# Altium schematic sheet Sheet1.SchDoc
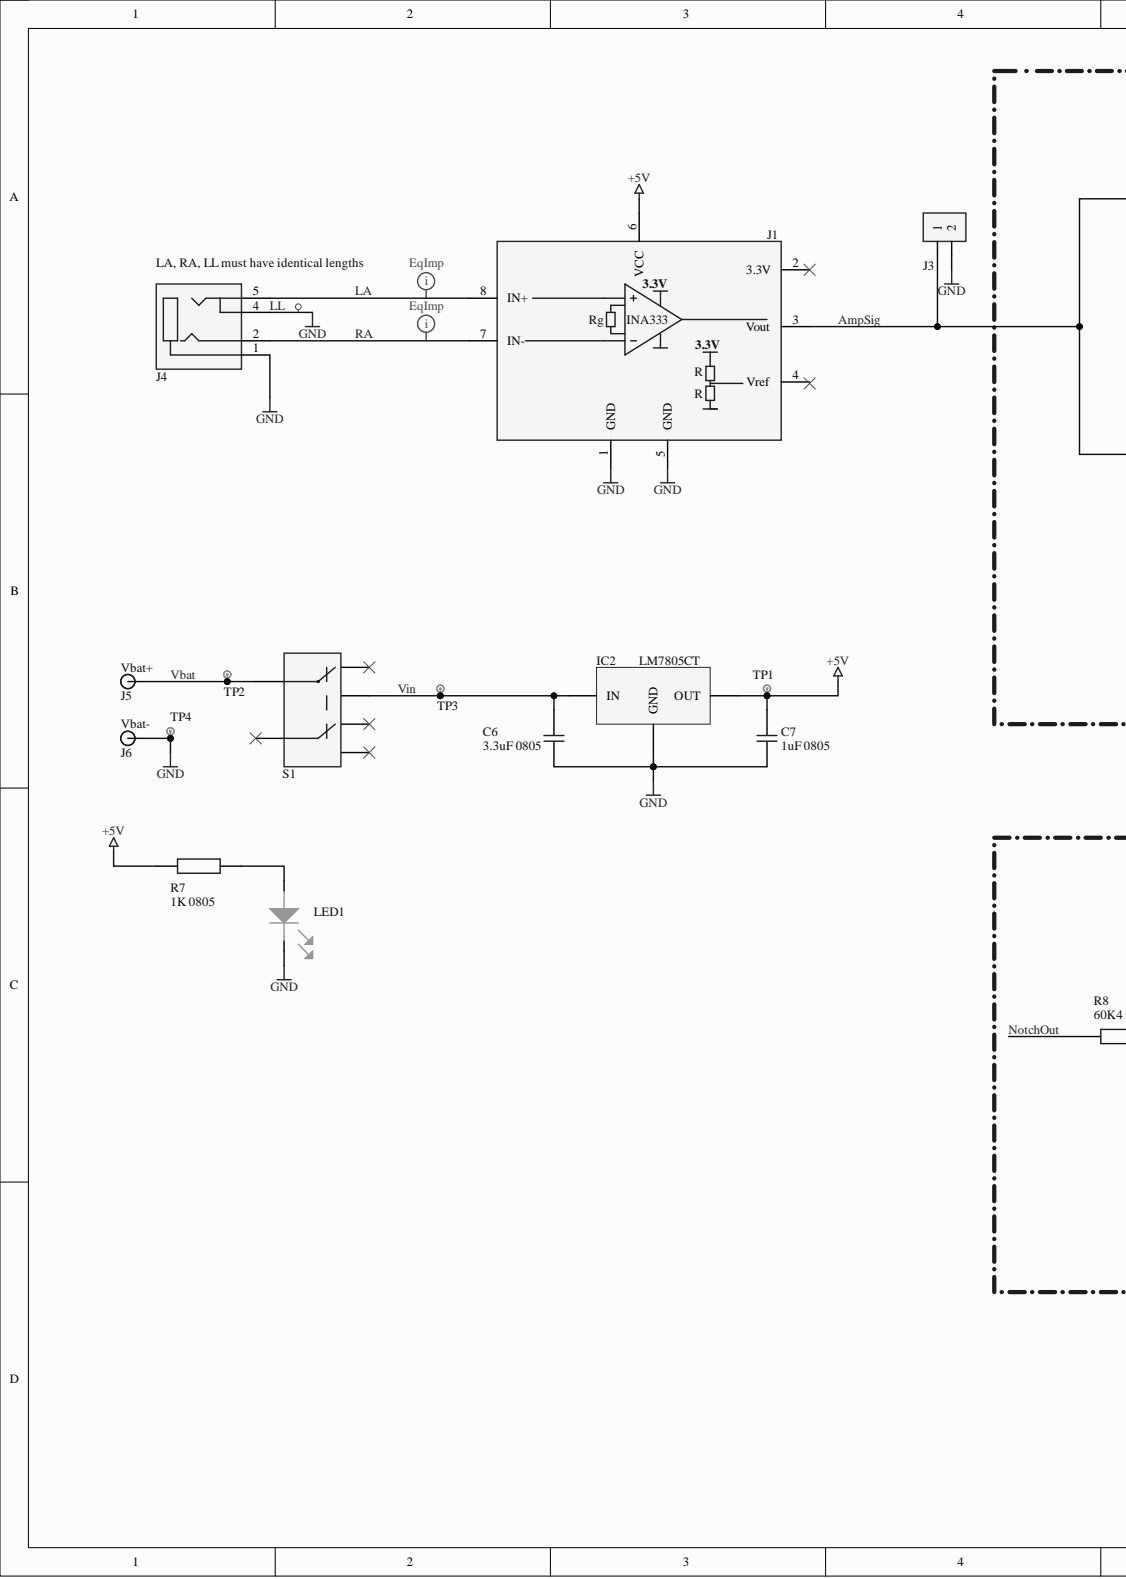
[diagram p0: Sheet1.SchDoc, left]
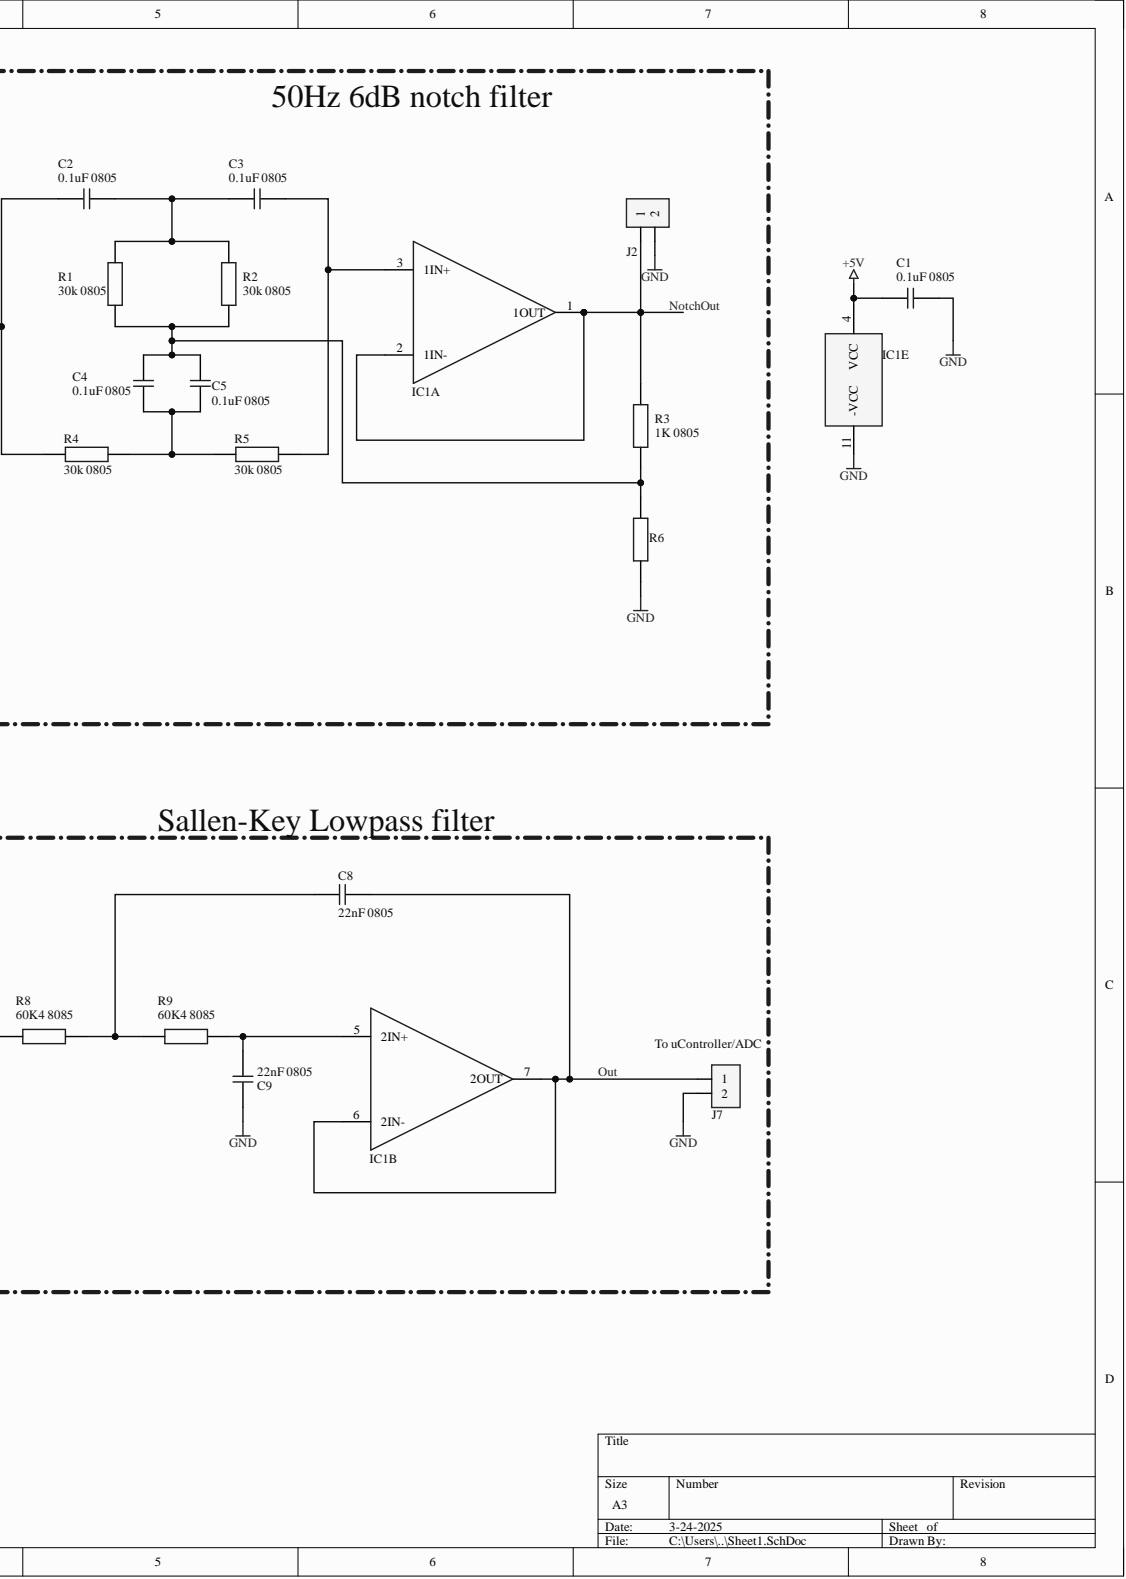
[diagram p1: Sheet1.SchDoc, right]

## Parts
C1  0.1uF 0805  Case/Package: 0805  [C0805 - XL]
C2  0.1uF 0805  Case/Package: 0805  [C0805 - XL]
C3  0.1uF 0805  Case/Package: 0805  [C0805 - XL]
C4  0.1uF 0805  Case/Package: 0805  [C0805 - XL]
C5  0.1uF 0805  Case/Package: 0805  [C0805 - XL]
C6  3.3uF 0805  value 3.3uF  Case/Package: 0805  [C0805 - XL]
C7  1uF 0805  value 1uF  Case/Package: 0805  [C0805 - XL]
C8  22nF 0805  value 22nF  Case/Package: 0805  [C0805 - XL]
C9  22nF 0805  value 22nF  Case/Package: 0805  [C0805 - XL]
IC1  LM324N  [DIP14 -  socket]  (value not drawn)  (parts A,B,E)
IC2  LM7805CT  [TO-220-3]
J1  INA333 Module  [INA333 - Module]  (value not drawn)  (no BOM)
J2  961102-6404-AR-HDR2-SIL  [HDR1X2-961102-6404-AR]  (value not drawn)
J3  961102-6404-AR-HDR2-SIL  [HDR1X2-961102-6404-AR]  (value not drawn)
J4  FC681374V  [FC681374V]  (value not drawn)
J5  Vbat+  [Soldering pads]  (no BOM)
J6  Vbat-  [Soldering pads]  (no BOM)
J7  961102-6404-AR-HDR2-SIL  [HDR1X2-961102-6404-AR]  (value not drawn)
LED1  Green LED  [LED 5mm THT]  (value not drawn)
R1  30k 0805  Case/Package: 0805  [R0805 - XL]
R2  30k 0805  Case/Package: 0805  [R0805 - XL]
R3  1K 0805  Case/Package: 0805  [R0805 - XL]
R4  30k 0805  Case/Package: 0805  [R0805 - XL]
R5  30k 0805  Case/Package: 0805  [R0805 - XL]
R6  19K1  [R0805 - XL]  (value not drawn)
R7  1K 0805  Case/Package: 0805  [R0805 - XL]
R8  60K4 8085  [R0805 - XL]
R9  60K4 8085  [R0805 - XL]
S1  SS22F03-G6  [SWDPDT-S22F03-G6]  (value not drawn)
TP1  Test pad  [Test pad 1mm]  (value not drawn)  (no BOM)
TP2  Test pad  [Test pad 1mm]  (value not drawn)  (no BOM)
TP3  Test pad  [Test pad 1mm]  (value not drawn)  (no BOM)
TP4  Test pad  [Test pad 1mm]  (value not drawn)  (no BOM)

## Nets
+5V: C1.2 C7.2 IC1.4(VCC) IC2.3 J1.6(VCC) R7.1 TP1.1
AmpSig: C2.1 J1.3(Vout) J3.1 R4.2
LA: J1.8(IN+) J4.5
LL: C1.1 C6.1 C7.1 C9.1 IC1.11(-VCC) IC2.2 J1.1(GND) J1.5(GND) J2.2 J3.2 J4.1 J4.4 J6.1 J7.2 LED1.2 R6.1 TP4.1
NotchOut: IC1.1(1OUT) IC1.2(1IN-) J2.1 R3.2 R8.1
Out: C8.1 IC1.6(2IN-) IC1.7(2OUT) J7.1
RA: J1.7(IN-) J4.2
Vbat: J5.1 S1.2 TP2.1
Vin: C6.2 IC2.1 S1.3 TP3.1
NetC2_2: C2.2 C3.1 R1.2 R2.2
NetC3_2: C3.2 IC1.3(1IN+) R5.1
NetC4_1: C4.1 C5.1 R4.1 R5.2
NetC4_2: C4.2 C5.2 R1.1 R2.1 R3.1 R6.2
NetC8_2: C8.2 R8.2 R9.1
NetC9_2: C9.2 IC1.5(2IN+) R9.2
NetLED1_1: LED1.1 R7.2

Not connected: J1 2(3.3V) 4(Vref); S1 1 4 5 6

## Library data of the parts
C1-C5 = 0.1uF 0805B104K500CT: Ceramic Capacitor, Multilayer, Ceramic, 50V, 10% +Tol, 10% -Tol, X7R, 15% TC, 0.1uF, Surface Mount, 0805; Manufacturer: Walsin Technologies; Multilayer: Yes; Value: 100nF; Capacitor Type: Ceramic; Category: Ceramic Capacitors; Component Kind: Standard; ComponentLink1Description: https://datasheet.ciiva.com:443/pdfs/VipMasterIC/IC/WATC/WATC-S-A0009550342/WATC-S-A0009550342-1.pdf?src-supplier=IHS+Markit; ComponentLink1URL: https://datasheet.ciiva.com:443/pdfs/VipMasterIC/IC/WATC/WATC-S-A0009550342/WATC-S-A0009550342-1.pdf?src-supplier=IHS+Markit; ComponentLink2Description: http://www.farnell.com/datasheets/2340522.pdf?src-supplier=Farnell; ComponentLink2URL: http://www.farnell.com/datasheets/2340522.pdf?src-supplier=Farnell; ComponentLink3Description: http://www.passivecomponent.com/indexsea/0805B104K500CT-IB.pdf?src-supplier=Digikey; ComponentLink3URL: http://www.passivecomponent.com/indexsea/0805B104K500CT-IB.pdf?src-supplier=Digikey; ComponentLink4Description: http://www.passivecomponent.com/wp-content/uploads/2018/10/MLCC.pdf?src-supplier=Digikey; ComponentLink4URL: http://www.passivecomponent.com/wp-content/uploads/2018/10/MLCC.pdf?src-supplier=Digikey; ComponentLink5Description: http://www.farnell.com/datasheets/2340522.pdf?src-supplier=Element14; ComponentLink5URL: http://www.farnell.com/datasheets/2340522.pdf?src-supplier=Element14; ComponentLink6Description: https://datasheet.octopart.com/0805B104K500CT-Walsin-Technologies-datasheet-128065749.pdf?src-supplier=Future+Electronics; ComponentLink6URL: https://datasheet.octopart.com/0805B104K500CT-Walsin-Technologies-datasheet-128065749.pdf?src-supplier=Future+Electronics; Dielectric Material: Ceramic; Height: 0.8mm; JESD-609 Code: e3; Length: 2mm; Library Name: MYLIB.IntLib; Manufacturer Part Number: 0805B104K500CT; Max Operating Temperature: 125°C; Min Operating Temperature: -55°C; Mounting Technology: Surface Mount; Package Shape: Rectangular; Package Style: SMTMeter; Packaging: Tape and Reel; Pins: 2; Pricing: 1=0.0564, 10=0.0292, 100=0.0134, 1000=0.00388, 4000=0.00315, 8000=0.00305, 20000=0.00299, 40000=0.00295, 80000=0.00291, 160000=0.00289 (EUR); Rated DC Voltage (URdc): 50V; Reach SVHC Compliant: Yes; RoHS: Yes; RoHS Compliant: Yes; Stock: 6960805; Supplier: TME; Supplier Part Number: 0805B104K500CT; Temperature Characteristics Code: X7R; Terminal Finish: Nickel; Termination: Wraparound; Tolerance  (fill in as +-): 10%; Tolerance (fill in as +-): 10%; Width: 1.25mm
C6 = 3.3uF 0805X335K100CT: Ceramic Capacitor, Multilayer, Ceramic, 10V, 10% +Tol, 10% -Tol, X5R, 15% TC, 3.3uF, Surface Mount, 0805; Manufacturer: Walsin Technologies; Multilayer: Yes; Capacitor Type: Ceramic; Category: Ceramic Capacitors; Component Kind: Standard; ComponentLink1Description: https://datasheet.ciiva.com:443/pdfs/VipMasterIC/IC/WATC/WATC-S-A0009550342/WATC-S-A0009550342-1.pdf?src-supplier=IHS+Markit; ComponentLink1URL: https://datasheet.ciiva.com:443/pdfs/VipMasterIC/IC/WATC/WATC-S-A0009550342/WATC-S-A0009550342-1.pdf?src-supplier=IHS+Markit; ComponentLink2Description: https://datasheet.ciiva.com/26864/getdatasheetpartid-415347-26864798.pdf?src-supplier=Verical; ComponentLink2URL: https://datasheet.ciiva.com/26864/getdatasheetpartid-415347-26864798.pdf?src-supplier=Verical; Dielectric Material: Ceramic; Height: 1.25mm; JESD-609 Code: e3; Length: 2mm; Library Name: MYLIB.IntLib; Manufacturer Part Number: 0805X335K100CT; Max Operating Temperature: 85°C; Min Operating Temperature: -55°C; Mounting Technology: Surface Mount; Package Shape: Rectangular; Package Style: SMTMeter; Packaging: Tape and Reel; Pins: 2; Pricing: 1=0.1894, 5=0.1297, 10=0.1085, 50=0.0684, 100=0.0569, 250=0.0462, 500=0.0407, 3000=0.0325, 45000=0.0281, 99000=0.0276 (EUR); Rated DC Voltage (URdc): 10V; Reach SVHC Compliant: Yes; RoHS: Yes; RoHS Compliant: Yes; Stock: 24211; Supplier: TME; Supplier Part Number: 0805X335K100CT; Temperature Characteristics Code: X5R; Terminal Finish: Nickel; Termination: Wraparound; Tolerance  (fill in as +-): 10%; Tolerance (fill in as +-): 10%; Width: 1.25mm
C7 = 1uF 0805B105K250CT: Ceramic Capacitor, Multilayer, Ceramic, 25V, 10% +Tol, 10% -Tol, X7R, 15% TC, 1uF, Surface Mount, 0805; Manufacturer: Walsin Technologies; Multilayer: Yes; Capacitor Type: Ceramic; Category: Ceramic Capacitors; Component Kind: Standard; ComponentLink1Description: https://datasheet.ciiva.com:443/pdfs/VipMasterIC/IC/WATC/WATC-S-A0009550342/WATC-S-A0009550342-1.pdf?src-supplier=IHS+Markit; ComponentLink1URL: https://datasheet.ciiva.com:443/pdfs/VipMasterIC/IC/WATC/WATC-S-A0009550342/WATC-S-A0009550342-1.pdf?src-supplier=IHS+Markit; ComponentLink2Description: http://www.farnell.com/datasheets/2340522.pdf?src-supplier=Farnell; ComponentLink2URL: http://www.farnell.com/datasheets/2340522.pdf?src-supplier=Farnell; ComponentLink3Description: https://www.verical.com/server-webapp/html/getDatasheet?partID=414515&src-supplier=Verical; ComponentLink3URL: https://www.verical.com/server-webapp/html/getDatasheet?partID=414515&src-supplier=Verical; ComponentLink4Description: http://www.farnell.com/datasheets/1958513.pdf?src-supplier=Element14; ComponentLink4URL: http://www.farnell.com/datasheets/1958513.pdf?src-supplier=Element14; ComponentLink5Description: https://datasheet.octopart.com/0805B105K250CT-Walsin-Technologies-datasheet-128065749.pdf?src-supplier=Future+Electronics; ComponentLink5URL: https://datasheet.octopart.com/0805B105K250CT-Walsin-Technologies-datasheet-128065749.pdf?src-supplier=Future+Electronics; ComponentLink6Description: https://datasheet.octopart.com/0805B105K250CT-Walsin-Technologies-datasheet-62066062.pdf?src-supplier=TME; ComponentLink6URL: https://datasheet.octopart.com/0805B105K250CT-Walsin-Technologies-datasheet-62066062.pdf?src-supplier=TME; ComponentLink7Description: https://datasheet.octopart.com/0805B105K250CT-Walsin-Technologies-datasheet-136037192.pdf?src-supplier=TECHDesign; ComponentLink7URL: https://datasheet.octopart.com/0805B105K250CT-Walsin-Technologies-datasheet-136037192.pdf?src-supplier=TECHDesign; Dielectric Material: Ceramic; Height: 1.25mm; JESD-609 Code: e3; Length: 2mm; Library Name: MYLIB.IntLib; Manufacturer Part Number: 0805B105K250CT; Max Operating Temperature: 125°C; Min Operating Temperature: -55°C; Mounting Technology: Surface Mount; Package Shape: Rectangular; Package Style: SMTMeter; Packaging: Tape and Reel; Pins: 2; Pricing: 1=0.1133, 10=0.027, 1000=0.0203, 3000=0.0185, 6000=0.0169, 9000=0.0154, 60000=0.0133, 75000=0.0126, 90000=0.012, 99000=0.0116 (EUR); Rated DC Voltage (URdc): 25V; Reach SVHC Compliant: Yes; RoHS: Yes; RoHS Compliant: Yes; Stock: 100383; Supplier: TME; Supplier Part Number: 0805B105K250CT; Temperature Characteristics Code: X7R; Terminal Finish: Nickel; Termination: Wraparound; Tolerance  (fill in as +-): 10%; Tolerance (fill in as +-): 10%; Width: 1.25mm
C8 C9 = 22nF 0805B223K500CT: Ceramic Capacitor, Multilayer, Ceramic, 50V, 10% +Tol, 10% -Tol, X7R, 15% TC, 0.022uF, Surface Mount, 0805; Manufacturer: Walsin Technologies; Multilayer: Yes; Capacitor Type: Ceramic; Category: Ceramic Capacitors; Component Kind: Standard; ComponentLink1Description: https://datasheet.ciiva.com:443/pdfs/VipMasterIC/IC/WATC/WATC-S-A0009550342/WATC-S-A0009550342-1.pdf?src-supplier=IHS+Markit; ComponentLink1URL: https://datasheet.ciiva.com:443/pdfs/VipMasterIC/IC/WATC/WATC-S-A0009550342/WATC-S-A0009550342-1.pdf?src-supplier=IHS+Markit; ComponentLink2Description: http://www.farnell.com/datasheets/1958513.pdf?src-supplier=Farnell; ComponentLink2URL: http://www.farnell.com/datasheets/1958513.pdf?src-supplier=Farnell; ComponentLink3Description: http://www.farnell.com/datasheets/2340522.pdf?src-supplier=Farnell; ComponentLink3URL: http://www.farnell.com/datasheets/2340522.pdf?src-supplier=Farnell; ComponentLink4Description: https://www.verical.com/server-webapp/html/getDatasheet?partID=74398&src-supplier=Verical; ComponentLink4URL: https://www.verical.com/server-webapp/html/getDatasheet?partID=74398&src-supplier=Verical; ComponentLink5Description: https://datasheet.octopart.com/0805B223K500CT-Walsin-Technologies-datasheet-85392065.pdf?src-supplier=Element14; ComponentLink5URL: https://datasheet.octopart.com/0805B223K500CT-Walsin-Technologies-datasheet-85392065.pdf?src-supplier=Element14; ComponentLink6Description: http://www.passivecomponent.com/wp-content/uploads/2018/10/MLCC.pdf?src-supplier=Digikey; ComponentLink6URL: http://www.passivecomponent.com/wp-content/uploads/2018/10/MLCC.pdf?src-supplier=Digikey; ComponentLink7Description: https://datasheet.octopart.com/0805B223K500CT-Walsin-Technologies-datasheet-128065749.pdf?src-supplier=Future+Electronics; ComponentLink7URL: https://datasheet.octopart.com/0805B223K500CT-Walsin-Technologies-datasheet-128065749.pdf?src-supplier=Future+Electronics; ComponentLink8Description: https://datasheet.octopart.com/0805B223K500CT-Walsin-Technologies-datasheet-136037192.pdf?src-supplier=TECHDesign; ComponentLink8URL: https://datasheet.octopart.com/0805B223K500CT-Walsin-Technologies-datasheet-136037192.pdf?src-supplier=TECHDesign; ComponentLink9Description: https://datasheet.octopart.com/0805B223K500CT-Walsin-Technologies-datasheet-62066062.pdf?src-supplier=TME; ComponentLink9URL: https://datasheet.octopart.com/0805B223K500CT-Walsin-Technologies-datasheet-62066062.pdf?src-supplier=TME; Dielectric Material: Ceramic; Height: 0.8mm; JESD-609 Code: e3; Length: 2mm; Library Name: MYLIB.IntLib; Manufacturer Part Number: 0805B223K500CT; Max Operating Temperature: 125°C; Min Operating Temperature: -55°C; Mounting Technology: Surface Mount; Package Shape: Rectangular; Package Style: SMTMeter; Packaging: Tape and Reel; Pins: 2; Pricing: 1=0.0855, 10=0.0209, 50=0.0147, 100=0.0129, 500=0.0097, 1000=0.0087, 3000=0.0075, 4000=0.0073, 8000=0.0067, 20000=0.0061 (EUR); Rated DC Voltage (URdc): 50V; Reach SVHC Compliant: Yes; RoHS: Yes; RoHS Compliant: Yes; Stock: 52340; Supplier: TME; Supplier Part Number: 0805B223K500CT; Temperature Characteristics Code: X7R; Terminal Finish: Nickel; Termination: Wraparound; Tolerance  (fill in as +-): 10%; Tolerance (fill in as +-): 10%; Width: 1.25mm
IC1 = LM324N-Socketed: Operational Amplifier, 4 Func, 9000uV Offset-Max, BIPolar, PDIP14; Manufacturer: Texas Instruments; Architecture: VOLTAGE-FEEDBACK; Average Bias Current-Max (IIB): 500nA; Bias Current-Max (IIB) @25C: 250nA; Channels: 4; Common Mode Rejection Ratio-Min: 65dB; Common-mode Reject Ratio-Nom: 85dB; Frequency Compensation: YES; Input Offset Voltage-Max: 9mV; Low-Offset: No; Max Supply Current: 1.2mA; Neg Supply Voltage Limit-Max: 0V; Nominal Supply Voltage: 5V; Package Body Material: Plastic; Package Equivalence Code: DIP14,.3; Power Supplies: 5/30V; Qualification Status: Not Qualified; Slew Rate: 0.5V/µs; Supply Voltage Limit-Max: 32V; Surface Mount: No; Time @ Peak Reflow Temperature-Max (s): 40s; Unity Gain BW-Nom: 1MHz; Voltage Gain-Min: 25000; Amplifier Type: OPERATIONAL AMPLIFIER; Case/Package: DIP; Category: Amplifiers - Op Amps, Buffer, Instrumentation; Component Kind: Standard; ComponentLink1Description: https://datasheet.ciiva.com:443/pdfs/VipMasterIC/IC/NATL/NATLS09708/NATLS09708-1.pdf?src-supplier=IHS+Markit; ComponentLink1URL: https://datasheet.ciiva.com:443/pdfs/VipMasterIC/IC/NATL/NATLS09708/NATLS09708-1.pdf?src-supplier=IHS+Markit; ComponentLink2Description: https://datasheet.ciiva.com/5997/1768103-5997424.pdf?src-supplier=Farnell; ComponentLink2URL: https://datasheet.ciiva.com/5997/1768103-5997424.pdf?src-supplier=Farnell; ComponentLink3Description: https://datasheet.ciiva.com/29304/151880-da-01-en-ic-lm-324-n-tid-29304983.pdf?src-supplier=Conrad; ComponentLink3URL: https://datasheet.ciiva.com/29304/151880-da-01-en-ic-lm-324-n-tid-29304983.pdf?src-supplier=Conrad; ComponentLink4Description: https://datasheet.ciiva.com/8008/1905671-8008853.pdf?src-supplier=Element14; ComponentLink4URL: https://datasheet.ciiva.com/8008/1905671-8008853.pdf?src-supplier=Element14; ComponentLink5Description: https://datasheet.ciiva.com/7709/1840878-7709815.pdf?src-supplier=Element14; ComponentLink5URL: https://datasheet.ciiva.com/7709/1840878-7709815.pdf?src-supplier=Element14; ComponentLink6Description: https://datasheet.ciiva.com/7709/1840878-7709816.pdf?src-supplier=Element14; ComponentLink6URL: https://datasheet.ciiva.com/7709/1840878-7709816.pdf?src-supplier=Element14; ComponentLink7Description: https://datasheet.ciiva.com/1796/lm224-1796723.pdf?src-supplier=Digikey; ComponentLink7URL: https://datasheet.ciiva.com/1796/lm224-1796723.pdf?src-supplier=Digikey; ComponentLink8Description: https://datasheet.ciiva.com/7148/lm224-7148869.pdf?src-supplier=Newark; ComponentLink8URL: https://datasheet.ciiva.com/7148/lm224-7148869.pdf?src-supplier=Newark; Height - Seated (Max): 5.08mm; JESD-30 Code: R-PDIP-T14; JESD-609 Code: e0; Length: 19.18mm; Manufacturer Part Number: LM324N; Max Operating Temperature: 70°C; Micropower: Yes; Min Operating Temperature: 0°C; Neg Supply Voltage-Nom (Vsup): 0V; Package Shape: Rectangular; Package Style: IN-LINEMeter; Peak Reflow Temperature (Cel): 260°C; Pins: 14; Pricing: 1=0.475, 10=0.333, 25=0.266, 100=0.238, 250=0.2, 500=0.18, 1000=0.166, 2500=0.154 (EUR); Reach SVHC Compliant: No; RoHS: No; RoHS Compliant: No; Stock: 5335; Supplier: TME; Supplier Part Number: LM324N-TI; Technology: BIPOLAR; Temperature Grade: COMMERCIAL; Terminal Finish: Tin; Terminal Form: Through Hole; Terminal Pitch: 2.54mm; Terminal Position: DUAL; Width: 7.62mm; Library Name: EKG.SchLib
IC2 = LM7805CT/NOPB: Fixed Positive Standard Regulator, 5VBIPolar, PSFM3; Manufacturer: Texas Instruments; Channels: 1; Max Supply Voltage: 25V; Min Input Voltage: 7V; Output Current1-Max: 1A; Output Voltage1-Max: 5.25V; Output Voltage1-Min: 4.75V; Output Voltage1-Nom: 5V; Package Body Material: Plastic; Qualification Status: Not Qualified; Regulator Type: FIXED POSITIVE SINGLE OUTPUT STANDARD REGULATOR; Surface Mount: No; Category: Voltage Regulators - Linear; Component Kind: Standard; ComponentLink1Description: https://datasheet.ciiva.com:443/pdfs/VipMasterIC/IC/NATL/NATLS06109/NATLS06109-1.pdf?src-supplier=IHS+Markit; ComponentLink1URL: https://datasheet.ciiva.com:443/pdfs/VipMasterIC/IC/NATL/NATLS06109/NATLS06109-1.pdf?src-supplier=IHS+Markit; ComponentLink2Description: https://datasheet.ciiva.com/14280/lm340-n-14280911.pdf?src-supplier=Avnet; ComponentLink2URL: https://datasheet.ciiva.com/14280/lm340-n-14280911.pdf?src-supplier=Avnet; ComponentLink3Description: https://datasheet.ciiva.com/15083/lm7805c-15083790.pdf?src-supplier=Digikey; ComponentLink3URL: https://datasheet.ciiva.com/15083/lm7805c-15083790.pdf?src-supplier=Digikey; ComponentLink4Description: https://datasheet.ciiva.com/45987/902071-45987836.pdf?src-supplier=Jameco; ComponentLink4URL: https://datasheet.ciiva.com/45987/902071-45987836.pdf?src-supplier=Jameco; JESD-30 Code: R-PSFM-T3; Library Name: MYLIB.IntLib; Manufacturer Part Number: LM7805CT/NOPB; Operating Temperature, TJ-Max: 125°C; Operating Temperature, TJ-Min: 0°C; Package Shape: Rectangular; Package Style: FLANGE MOUNTMeter; Pins: 3; Pricing: 1=1.21, 45=1.13, 90=1.07, 270=0.92, 450=0.84, 540=0.81, 675=0.78, 1035=0.77 (EUR); RoHS: Yes; RoHS Compliant: Yes; Stock: 1029; Supplier: TME; Supplier Part Number: LM7805CT/NOPB; Technology: BIPOLAR; Terminal Form: Through Hole; Terminal Position: SINGLE
J1 = INA333 Module: INA333 Module mounting holes; Library Name: MYLIB.IntLib
J2 J3 J7 = HDR2x1-961102-6404-AR: Pin Strip Header 2PIN SIL; Component Kind: Standard; Library Name: MYLIB.IntLib
J4 = FC681374V: DC Power Plug or Jack.                     Contact Resistance: 30m max. W  Insulation Resistance: 100M min. at DC 500V W  Dielectric Withstand Voltage: AC 500V for 1 minute; Manufacturer: Cliff; Category: Audio / Video Connectors; Component Kind: Standard; ComponentLink1Description: http://www.produktinfo.conrad.com/datenblaetter/1300000-1399999/001317833-da-01-en-3_5_MM_KLINKENEINBAUBUCHSE_FC681374V.pdf?src-supplier=Conrad; ComponentLink1URL: http://www.produktinfo.conrad.com/datenblaetter/1300000-1399999/001317833-da-01-en-3_5_MM_KLINKENEINBAUBUCHSE_FC681374V.pdf?src-supplier=Conrad; ComponentLink2Description: http://datasheet.octopart.com/FC681374V-Cliff-datasheet-27593909.pdf?src-supplier=Distrelec; ComponentLink2URL: http://datasheet.octopart.com/FC681374V-Cliff-datasheet-27593909.pdf?src-supplier=Distrelec; ComponentLink3Description: http://datasheet.octopart.com/FC681374V-Cliff-datasheet-27593909.pdf?src-supplier=Farnell; ComponentLink3URL: http://datasheet.octopart.com/FC681374V-Cliff-datasheet-27593909.pdf?src-supplier=Farnell; ComponentLink4Description: http://www.farnell.com/datasheets/1869285.pdf?src-supplier=Element14; ComponentLink4URL: http://www.farnell.com/datasheets/1869285.pdf?src-supplier=Element14; ComponentLink5Description: https://datasheet.octopart.com/FC681374V-Cliff-datasheet-27593909.pdf?src-supplier=Newark; ComponentLink5URL: https://datasheet.octopart.com/FC681374V-Cliff-datasheet-27593909.pdf?src-supplier=Newark; ComponentLink6Description: https://datasheet.octopart.com/FC681374V-Cliff-datasheet-34177465.pdf?src-supplier=TME; ComponentLink6URL: https://datasheet.octopart.com/FC681374V-Cliff-datasheet-34177465.pdf?src-supplier=TME; Library Name: MYLIB.IntLib; Manufacturer Part Number: FC681374V; Pricing: 1=1.25, 20=1.09, 100=0.97, 500=0.76 (EUR); RoHS: Yes; Stock: 654; Supplier: TME; Supplier Part Number: FC681374V
J5 J6 = Soldering Pads: 1mm holes for wires; Library Name: MYLIB.IntLib
LED1 = LED G: 5mm Green LED; Component Kind: Standard; Library Name: MYLIB.IntLib
R1 R2 R4 R5 = R30K ERJ-6ENF3002V: Fixed Resistor, Metal Glaze/thick Film, 0.125W, 30000ohm, 150V, 1% +/-Tol, 100ppm/Cel, Surface Mount, 0805; Manufacturer: Panasonic; Package Height: 0.6mm; Package Length: 2mm; Package Width: 1.25mm; Power: 125mW; Rated Temperature: 70°C; Reference Standard: AEC-Q200; Temperature Coefficient (ppm/Cel): 100; Value: 30kR; Category: Chip SMD Resistors; Component Kind: Standard; ComponentLink1Description: https://datasheet.ciiva.com:443/pdfs/VipMasterIC/IC/PANA/PANA-S-A0009889626/PANA-S-A0009889626-1.pdf?src-supplier=IHS+Markit; ComponentLink1URL: https://datasheet.ciiva.com:443/pdfs/VipMasterIC/IC/PANA/PANA-S-A0009889626/PANA-S-A0009889626-1.pdf?src-supplier=IHS+Markit; ComponentLink2Description: https://datasheet.ciiva.com/11821/0900766b80f80784-11821600.pdf?src-supplier=Rs; ComponentLink2URL: https://datasheet.ciiva.com/11821/0900766b80f80784-11821600.pdf?src-supplier=Rs; ComponentLink3Description: https://datasheet.ciiva.com/24124/aoa0000ce2-24124393.pdf?src-supplier=Mouser; ComponentLink3URL: https://datasheet.ciiva.com/24124/aoa0000ce2-24124393.pdf?src-supplier=Mouser; ComponentLink4Description: https://datasheet.ciiva.com/22673/1678782-22673293.pdf?src-supplier=Element14; ComponentLink4URL: https://datasheet.ciiva.com/22673/1678782-22673293.pdf?src-supplier=Element14; ComponentLink5Description: https://datasheet.ciiva.com/28565/1694929-28565193.pdf?src-supplier=Element14; ComponentLink5URL: https://datasheet.ciiva.com/28565/1694929-28565193.pdf?src-supplier=Element14; ComponentLink6Description: https://datasheet.ciiva.com/46441/1678782-46441405.pdf?src-supplier=Element14; ComponentLink6URL: https://datasheet.ciiva.com/46441/1678782-46441405.pdf?src-supplier=Element14; ComponentLink7Description: https://datasheet.ciiva.com/46441/1678782-46441406.pdf?src-supplier=Element14; ComponentLink7URL: https://datasheet.ciiva.com/46441/1678782-46441406.pdf?src-supplier=Element14; ComponentLink8Description: https://datasheet.ciiva.com/7711/1678782-7711062.pdf?src-supplier=Element14; ComponentLink8URL: https://datasheet.ciiva.com/7711/1678782-7711062.pdf?src-supplier=Element14; ComponentLink9Description: https://datasheet.ciiva.com/9117/aoa0000ce2-9117485.pdf?src-supplier=Avnet; ComponentLink9URL: https://datasheet.ciiva.com/9117/aoa0000ce2-9117485.pdf?src-supplier=Avnet; ComponentLink10Description: https://datasheet.ciiva.com/30169/aoa0000ce2-30169447.pdf?src-supplier=Onlinecomponents.com; ComponentLink10URL: https://datasheet.ciiva.com/30169/aoa0000ce2-30169447.pdf?src-supplier=Onlinecomponents.com; Construction: Rectangular; JESD-609 Code: e3; Library Name: MYLIB.IntLib; Manufacturer Part Number: ERJ-6ENF3002V; Max Operating Temperature: 155°C; Min Operating Temperature: -55°C; Mounting Technology: Surface Mount; Package Shape: Rectangular; Packaging: Tape and Reel; Pins: 2; Pricing: 1=0.095, 10=0.0298, 50=0.0217, 100=0.0191, 500=0.0145, 1000=0.013, 5000=0.0102, 10000=0.0093, 250000=0.0088 (EUR); Reach SVHC Compliant: Yes; Resistor Type: FIXED RESISTOR; RoHS: Yes; RoHS Compliant: Yes; Stock: 4568; Supplier: TME; Supplier Part Number: ERJ6ENF3002V; Technology: METAL GLAZE/THICK FILM; Terminal Finish: Nickel; Termination: Wraparound; Tolerance: 1%; Working Voltage: 150V
R3 R7 = R1K - RCA08051K00FKEA: Fixed Resistor, Metal Glaze/thick Film, 0.125W, 1000ohm, 150V, 1% +/-Tol, 100ppm/Cel, Surface Mount, 0805; Manufacturer: Vishay Semiconductors; Additional Feature: ANTI-SULFUR; Package Height: 0.45mm; Package Length: 2mm; Package Width: 1.25mm; Power: 125mW; Rated Temperature: 70°C; Reference Standard: AEC-Q200; Temperature Coefficient (ppm/Cel): 100; Value: 1kR; Category: Chip SMD Resistors; Component Kind: Standard; ComponentLink1Description: https://datasheet.ciiva.com:443/pdfs/VipMasterIC/IC/VISH/VISH-S-A0002290271/VISH-S-A0002290271-1.pdf?src-supplier=IHS+Markit; ComponentLink1URL: https://datasheet.ciiva.com:443/pdfs/VipMasterIC/IC/VISH/VISH-S-A0002290271/VISH-S-A0002290271-1.pdf?src-supplier=IHS+Markit; ComponentLink2Description: https://datasheet.ciiva.com/8901/rcae3-8901866.pdf?src-supplier=Avnet; ComponentLink2URL: https://datasheet.ciiva.com/8901/rcae3-8901866.pdf?src-supplier=Avnet; Construction: Rectangular; JESD-609 Code: e3; Library Name: MYLIB.IntLib; Manufacturer Part Number: RCA08051K00FKEA; Max Operating Temperature: 155°C; Min Operating Temperature: -55°C; Mounting Technology: Surface Mount; Packaging: Tape and Reel; Pins: 2; Pricing: 10=0.0243, 50=0.0207, 100=0.0186, 500=0.0137, 1000=0.0118, 2500=0.0096, 5000=0.0082, 10000=0.007 (EUR); Reach SVHC Compliant: Yes; Resistor Type: FIXED RESISTOR; RoHS: Yes; RoHS Compliant: Yes; Stock: 3830; Supplier: TME; Supplier Part Number: RCA08051K00FKEA; Technology: METAL GLAZE/THICK FILM; Terminal Finish: Nickel; Termination: Wraparound; Tolerance: 1%; Working Voltage: 150V
R6 = R19K1 ERJ-6ENF1912V: Fixed Resistor, Metal Glaze/thick Film, 0.125W, 19100ohm, 150V, 1% +/-Tol, 100ppm/Cel, Surface Mount, 0805; Manufacturer: Panasonic; Package Height: 0.6mm; Package Length: 2mm; Package Width: 1.25mm; Power: 125mW; Rated Temperature: 70°C; Reference Standard: AEC-Q200; Temperature Coefficient (ppm/Cel): 100; Value: 19.1kR; Case/Package: 0805; Category: Chip SMD Resistors; Component Kind: Standard; ComponentLink1Description: https://datasheet.ciiva.com:443/pdfs/VipMasterIC/IC/PANA/PANA-S-A0009889626/PANA-S-A0009889626-1.pdf?src-supplier=IHS+Markit; ComponentLink1URL: https://datasheet.ciiva.com:443/pdfs/VipMasterIC/IC/PANA/PANA-S-A0009889626/PANA-S-A0009889626-1.pdf?src-supplier=IHS+Markit; ComponentLink2Description: https://datasheet.ciiva.com/11821/0900766b80f80784-11821546.pdf?src-supplier=Rs; ComponentLink2URL: https://datasheet.ciiva.com/11821/0900766b80f80784-11821546.pdf?src-supplier=Rs; ComponentLink3Description: https://datasheet.ciiva.com/22764/1678782-22764831.pdf?src-supplier=Farnell; ComponentLink3URL: https://datasheet.ciiva.com/22764/1678782-22764831.pdf?src-supplier=Farnell; ComponentLink4Description: https://datasheet.ciiva.com/9192/aoa0000ce2-9192286.pdf?src-supplier=Avnet; ComponentLink4URL: https://datasheet.ciiva.com/9192/aoa0000ce2-9192286.pdf?src-supplier=Avnet; ComponentLink5Description: https://datasheet.ciiva.com/24164/aoa0000ce2-24164678.pdf?src-supplier=Mouser; ComponentLink5URL: https://datasheet.ciiva.com/24164/aoa0000ce2-24164678.pdf?src-supplier=Mouser; ComponentLink6Description: https://datasheet.ciiva.com/16765/70260748-16765944.pdf?src-supplier=Allied+Electronics; ComponentLink6URL: https://datasheet.ciiva.com/16765/70260748-16765944.pdf?src-supplier=Allied+Electronics; ComponentLink7Description: https://datasheet.ciiva.com/16781/70260748-16781813.pdf?src-supplier=Allied+Electronics; ComponentLink7URL: https://datasheet.ciiva.com/16781/70260748-16781813.pdf?src-supplier=Allied+Electronics; ComponentLink8Description: https://datasheet.ciiva.com/30243/aoa0000ce2-30243470.pdf?src-supplier=Onlinecomponents.com; ComponentLink8URL: https://datasheet.ciiva.com/30243/aoa0000ce2-30243470.pdf?src-supplier=Onlinecomponents.com; ComponentLink9Description: https://datasheet.ciiva.com/22668/1678782-22668686.pdf?src-supplier=Element14; ComponentLink9URL: https://datasheet.ciiva.com/22668/1678782-22668686.pdf?src-supplier=Element14; Construction: Rectangular; JESD-609 Code: e3; Manufacturer Part Number: ERJ-6ENF1912V; Max Operating Temperature: 155°C; Min Operating Temperature: -55°C; Mounting Technology: Surface Mount; Package Shape: Rectangular; Packaging: Tape and Reel; Pins: 2; Pricing: 1=0.1045, 10=0.0287, 50=0.0228, 100=0.0205, 5000=0.0089, 10000=0.0086 (EUR); Reach SVHC Compliant: Yes; Resistor Type: FIXED RESISTOR; RoHS: Yes; RoHS Compliant: Yes; Stock: 4770; Supplier: TME; Supplier Part Number: ERJ6ENF1912V; Technology: METAL GLAZE/THICK FILM; Terminal Finish: Nickel; Termination: Wraparound; Tolerance: 1%; Working Voltage: 150V; Library Name: EKG.SchLib
R8 R9 = R60K4 ERJ-6ENF6042V: Fixed Resistor, Metal Glaze/thick Film, 0.125W, 60400ohm, 150V, 1% +/-Tol, 100ppm/Cel, Surface Mount, 0805; Manufacturer: Panasonic; Package Height: 0.6mm; Package Length: 2mm; Package Width: 1.25mm; Power: 125mW; Rated Temperature: 70°C; Reference Standard: AEC-Q200; Temperature Coefficient (ppm/Cel): 100; Value: 60.4kR; Case/Package: 0805; Category: Chip SMD Resistors; Component Kind: Standard; ComponentLink1Description: https://datasheet.ciiva.com:443/pdfs/VipMasterIC/IC/PANA/PANA-S-A0009889626/PANA-S-A0009889626-1.pdf?src-supplier=IHS+Markit; ComponentLink1URL: https://datasheet.ciiva.com:443/pdfs/VipMasterIC/IC/PANA/PANA-S-A0009889626/PANA-S-A0009889626-1.pdf?src-supplier=IHS+Markit; ComponentLink2Description: https://datasheet.ciiva.com/9188/aoa0000ce2-9188490.pdf?src-supplier=Avnet; ComponentLink2URL: https://datasheet.ciiva.com/9188/aoa0000ce2-9188490.pdf?src-supplier=Avnet; ComponentLink3Description: https://datasheet.ciiva.com/16781/70260748-16781596.pdf?src-supplier=Allied+Electronics; ComponentLink3URL: https://datasheet.ciiva.com/16781/70260748-16781596.pdf?src-supplier=Allied+Electronics; ComponentLink4Description: https://datasheet.ciiva.com/22706/426256-22706518.pdf?src-supplier=Element14; ComponentLink4URL: https://datasheet.ciiva.com/22706/426256-22706518.pdf?src-supplier=Element14; ComponentLink5Description: https://datasheet.ciiva.com/28693/1678782-28693609.pdf?src-supplier=Element14; ComponentLink5URL: https://datasheet.ciiva.com/28693/1678782-28693609.pdf?src-supplier=Element14; ComponentLink6Description: https://datasheet.ciiva.com/7861/426256-7861900.pdf?src-supplier=Element14; ComponentLink6URL: https://datasheet.ciiva.com/7861/426256-7861900.pdf?src-supplier=Element14; ComponentLink7Description: https://datasheet.ciiva.com/6064/426256-6064646.pdf?src-supplier=Farnell; ComponentLink7URL: https://datasheet.ciiva.com/6064/426256-6064646.pdf?src-supplier=Farnell; ComponentLink8Description: https://datasheet.ciiva.com/6064/426256-6064648.pdf?src-supplier=Farnell; ComponentLink8URL: https://datasheet.ciiva.com/6064/426256-6064648.pdf?src-supplier=Farnell; ComponentLink9Description: https://datasheet.ciiva.com/30063/aoa0000ce2-30063494.pdf?src-supplier=Onlinecomponents.com; ComponentLink9URL: https://datasheet.ciiva.com/30063/aoa0000ce2-30063494.pdf?src-supplier=Onlinecomponents.com; Construction: Rectangular; JESD-609 Code: e3; Library Name: MYLIB.IntLib; Manufacturer Part Number: ERJ-6ENF6042V; Max Operating Temperature: 155°C; Min Operating Temperature: -55°C; Mounting Technology: Surface Mount; Package Shape: Rectangular; Packaging: Tape and Reel; Pins: 2; Pricing: 1=0.0441, 50=0.0255, 100=0.0224, 250=0.0185, 500=0.0159, 1000=0.0136, 3000=0.0104, 5000=0.009, 10000=0.0086 (EUR); Reach SVHC Compliant: Yes; Resistor Type: FIXED RESISTOR; RoHS: Yes; RoHS Compliant: Yes; Stock: 4450; Supplier: TME; Supplier Part Number: ERJ6ENF6042V; Technology: METAL GLAZE/THICK FILM; Terminal Finish: Nickel; Termination: Wraparound; Tolerance: 1%; Working Voltage: 150V
S1 = SW-SS22F03-G6: Slide switch DPDT 0.5A/50V; Manufacturer: Ninigi; Component Kind: Standard; Library Name: MYLIB.IntLib; Manufacturer Part Number: SS22F03-G6; Pricing: 2=0.358, 5=0.303, 20=0.285, 100=0.257, 500=0.229 (EUR); RoHS: No; Stock: 2321; Supplier: TME; Supplier Part Number: SS22F03-G6
TP1-TP4 = Test Pad: 1mm test pad; Library Name: MYLIB.IntLib
